# Altium schematic sheet CAN.SchDoc
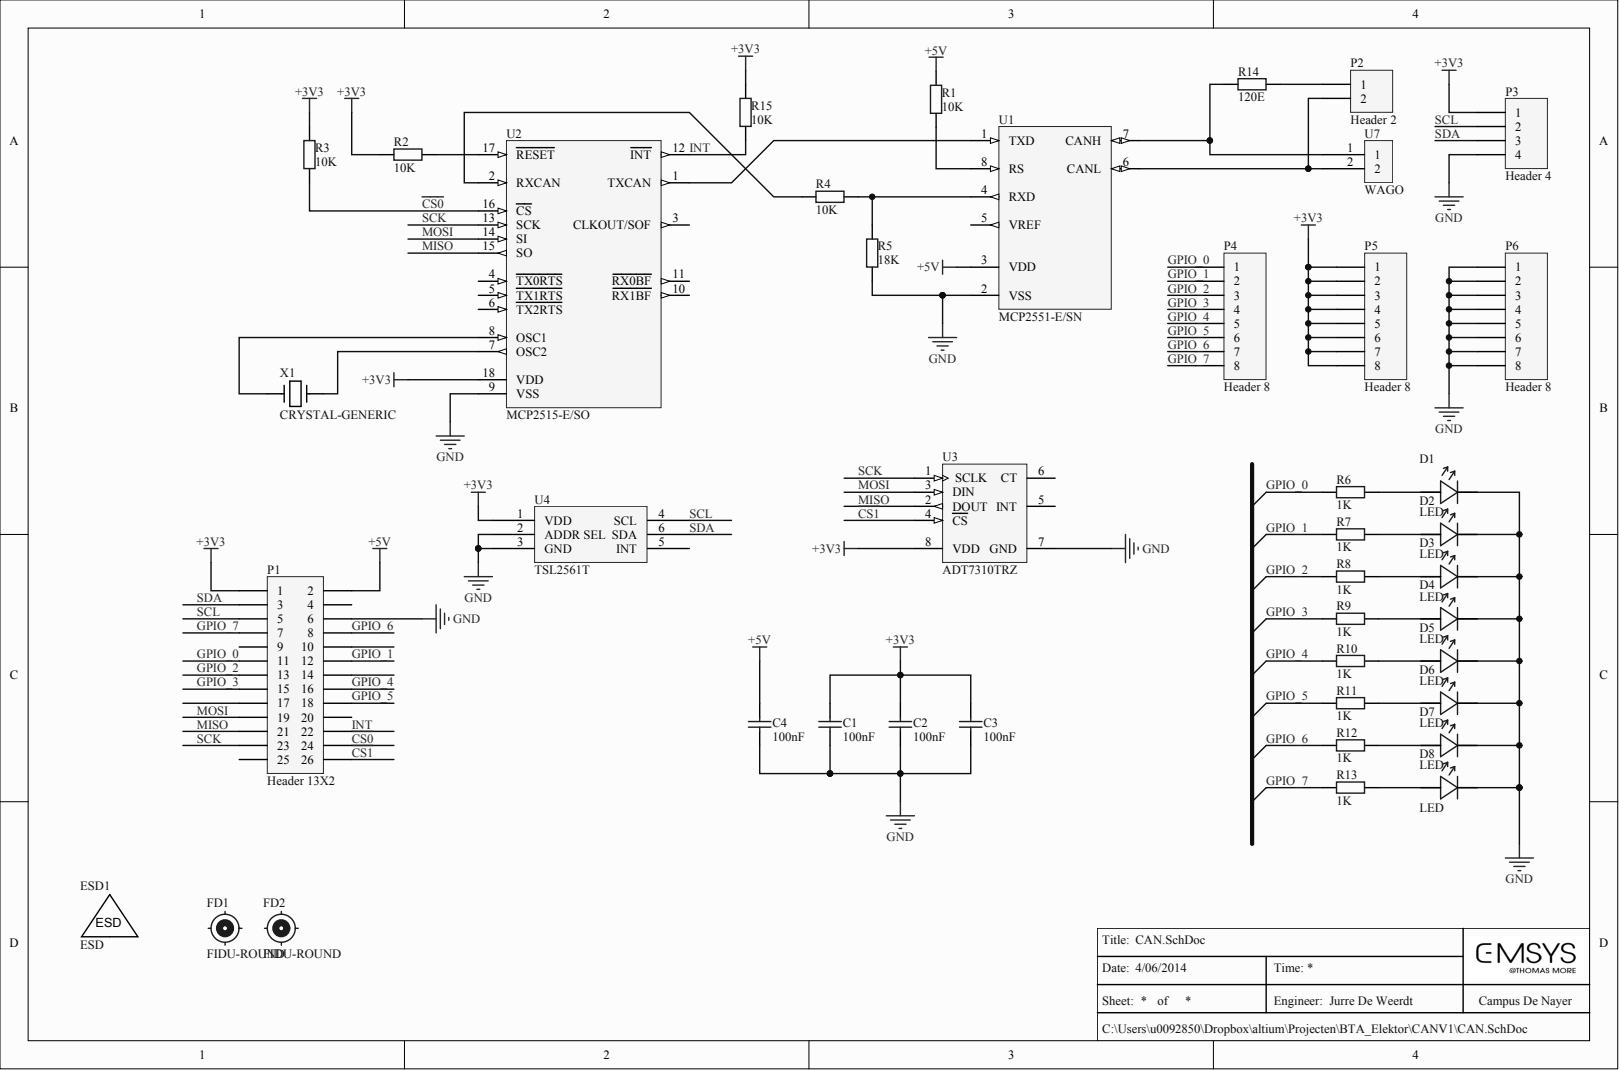
[diagram p0: CAN.SchDoc]

## Parts
C1  100nF
C2  100nF
C3  100nF
C4  100nF
D1  LED
D2  LED
D3  LED
D4  LED
D5  LED
D6  LED
D7  LED
D8  LED
ESD1  ESD
FD1  FIDU-ROUND
FD2  FIDU-ROUND
P1  Header 13X2
P2  Header 2
P3  Header 4
P4  Header 8
P5  Header 8
P6  Header 8
R1  10K
R2  10K
R3  10K
R4  10K
R5  18K
R6  1K
R7  1K
R8  1K
R9  1K
R10  1K
R11  1K
R12  1K
R13  1K
R14  120E
R15  10K
U1  MCP2551-E/SN
U2  MCP2515-E/SO
U3  ADT7310TRZ
U4  TSL2561T
U7  WAGO
X1  CRYSTAL-GENERIC

## Nets
+3V3: C1.2 C2.2 C3.2 P1.1 P3.1 P5.1 P5.2 P5.3 P5.4 P5.5 P5.6 P5.7 P5.8 R2.1 R3.2 R15.2 U2.18(VDD) U3.8(VDD) U4.1(VDD)
+5V: C4.2 P1.2 R1.2 U1.3(VDD)
GND: C1.1 C2.1 C3.1 C4.1 D1.2 D2.2 D3.2 D4.2 D5.2 D6.2 D7.2 D8.2 P1.6 P3.4 P6.1 P6.2 P6.3 P6.4 P6.5 P6.6 P6.7 P6.8 R5.1 U1.2(VSS) U2.9(VSS) U3.7(GND) U4.2 U4.3(GND)
~{CS0}: P1.24 R3.1 U2.16(CS)
~{CS1}: P1.26 U3.4(CS)
GPIO_0: P1.11 P4.1 R6.1
GPIO_1: P1.12 P4.2 R7.1
GPIO_2: P1.13 P4.3 R8.1
GPIO_3: P1.15 P4.4 R9.1
GPIO_4: P1.16 P4.5 R10.1
GPIO_5: P1.18 P4.6 R11.1
GPIO_6: P1.8 P4.7 R12.1
GPIO_7: P1.7 P4.8 R13.1
INT: P1.22 R15.1 U2.12(INT)
MISO: P1.21 U2.15(SO) U3.2(DOUT)
MOSI: P1.19 U2.14(SI) U3.3(DIN)
SCK: P1.23 U2.13(SCK) U3.1(SCLK)
SCL: P1.5 P3.2 U4.4(SCL)
SDA: P1.3 P3.3 U4.6(SDA)
NetD1_1: D1.1 R6.2
NetD2_1: D2.1 R7.2
NetD3_1: D3.1 R8.2
NetD4_1: D4.1 R9.2
NetD5_1: D5.1 R10.2
NetD6_1: D6.1 R11.2
NetD7_1: D7.1 R12.2
NetD8_1: D8.1 R13.2
NetP2_1: P2.1 R14.1
NetP2_2: P2.2 U1.6(CANL) U7.2
NetR1_1: R1.1 U1.8(RS)
NetR2_2: R2.2 U2.17(RESET)
NetR4_1: R4.1 U2.2(RXCAN)
NetR4_2: R4.2 R5.2 U1.4(RXD)
NetR14_2: R14.2 U1.7(CANH) U7.1
NetU1_1: U1.1(TXD) U2.1(TXCAN)
NetU2_7: U2.7(OSC2) X1.2
NetU2_8: U2.8(OSC1) X1.1
N00017:

Not connected: P1 4 9 10 14 17 20 25; U1 5(VREF); U2 3(CLKOUT/SOF) 4(TX0RTS) 5(TX1RTS) 6(TX2RTS) 10(RX1BF) 11(RX0BF); U3 5(INT) 6(CT); U4 5(INT)

## Library data of the parts
U1 U2 = (no symbol name): Manufacturer: Microchip; PackageVersion: revBB, Aug-2009; Supplier 1: Digi-Key; Supplier 2: Farnell; Supplier 3: Newark; Supplier 4: Mouser; Mounting Technology: Surface Mount; ComponentLink1Description: Manufacturer URL; ComponentLink1URL: http://www.microchip.com/; ComponentLink2Description: Datasheet; ComponentLink3Description: Package Specification; ComponentLink3URL: http://www.microchip.com/stellent/groups/techpub_sg/documents/packagingspec/en012702.pdf
  U1: DatasheetVersion: revF, Jul-2010; PackageReference: SOIC-SN8; PackageDescription: 8-Lead Plastic Small Outline (SN) - Narrow, 3.90mm Body [SOIC]; Supplier Part Number 1: MCP2551-E/SN-ND; Supplier Part Number 2: 1467746; Supplier Part Number 3: 92C4186; Supplier Part Number 4: 579-MCP2551-E/SN; Code_JEDEC: MS-012; Code_IPC: SOIC127P600-8; PartNumber: MCP2551-E/SN; ComponentLink2URL: http://ww1.microchip.com/downloads/en/DeviceDoc/21667F.pdf
  U2: DatasheetVersion: revF, Oct-2010; PackageReference: SOIC-SO18; PackageDescription: 18-Lead Plastic Small Outline (SO) - Wide 7.50mm Body [SOIC]; Supplier Part Number 1: MCP2515-E/SO-ND; Supplier Part Number 2: 1605565; Supplier Part Number 3: 29H7134; Supplier Part Number 4: 579-MCP2515-E/SO; Code_JEDEC: MS-013; PartNumber: MCP2515-E/SO; ComponentLink2URL: http://ww1.microchip.com/downloads/en/DeviceDoc/21801f.pdf
U3 = (no symbol name): Manufacturer: Analog Devices; Packing: Tube; Supply Voltage Range: 2.7 to 5.5 V; DatasheetVersion: Rev. A; PackageVersion: 060506-A, 06/2006; PackageReference: R-8; PackageDescription: 8-Pin Standard Small Outline Package, Body 4.9 x 3.9 mm, Pitch 1.27 mm; Supplier 1: Digi-Key; Supplier Part Number 1: ADT7310TRZ-ND; Supplier 2: Farnell; Supplier Part Number 2: 2067805; Supplier 3: Newark; Supplier Part Number 3: 08R6643; Supplier 4: Mouser; Supplier Part Number 4: 584-ADT7310TRZ; Mounting Technology: Surface Mount; Code_JEDEC: MS-012-AA; PartNumber: ADT7310TRZ; ComponentLink1Description: Manufacturer URL; ComponentLink1URL: http://www.analog.com/en/index.html; ComponentLink2Description: Datasheet; ComponentLink2URL: http://www.analog.com/static/imported-files/data_sheets/ADT7310.pdf; ComponentLink3Description: Package Specification; ComponentLink3URL: http://www.analog.com/static/imported-files/packages/PKG_PDF/SOIC_Narrow%20(R)/R_8.pdf; ComponentLink4Description: Product URL; ComponentLink4URL: http://www.analog.com/en/mems-sensors/digital-temperature-sensors/adt7310/products/product.html
C1-C4 D1-D8 ESD1 FD1 FD2 P1-P6 R1-R15 U4 U7 X1 = (no symbol name)
  P1-P6: LatestRevisionDate: 17-Jul-2002; LatestRevisionNote: Re-released for DXP Platform.; Publisher: Altium Limited
